# Altium schematic sheet 020_USBPort.SchDoc(020_USBPort)
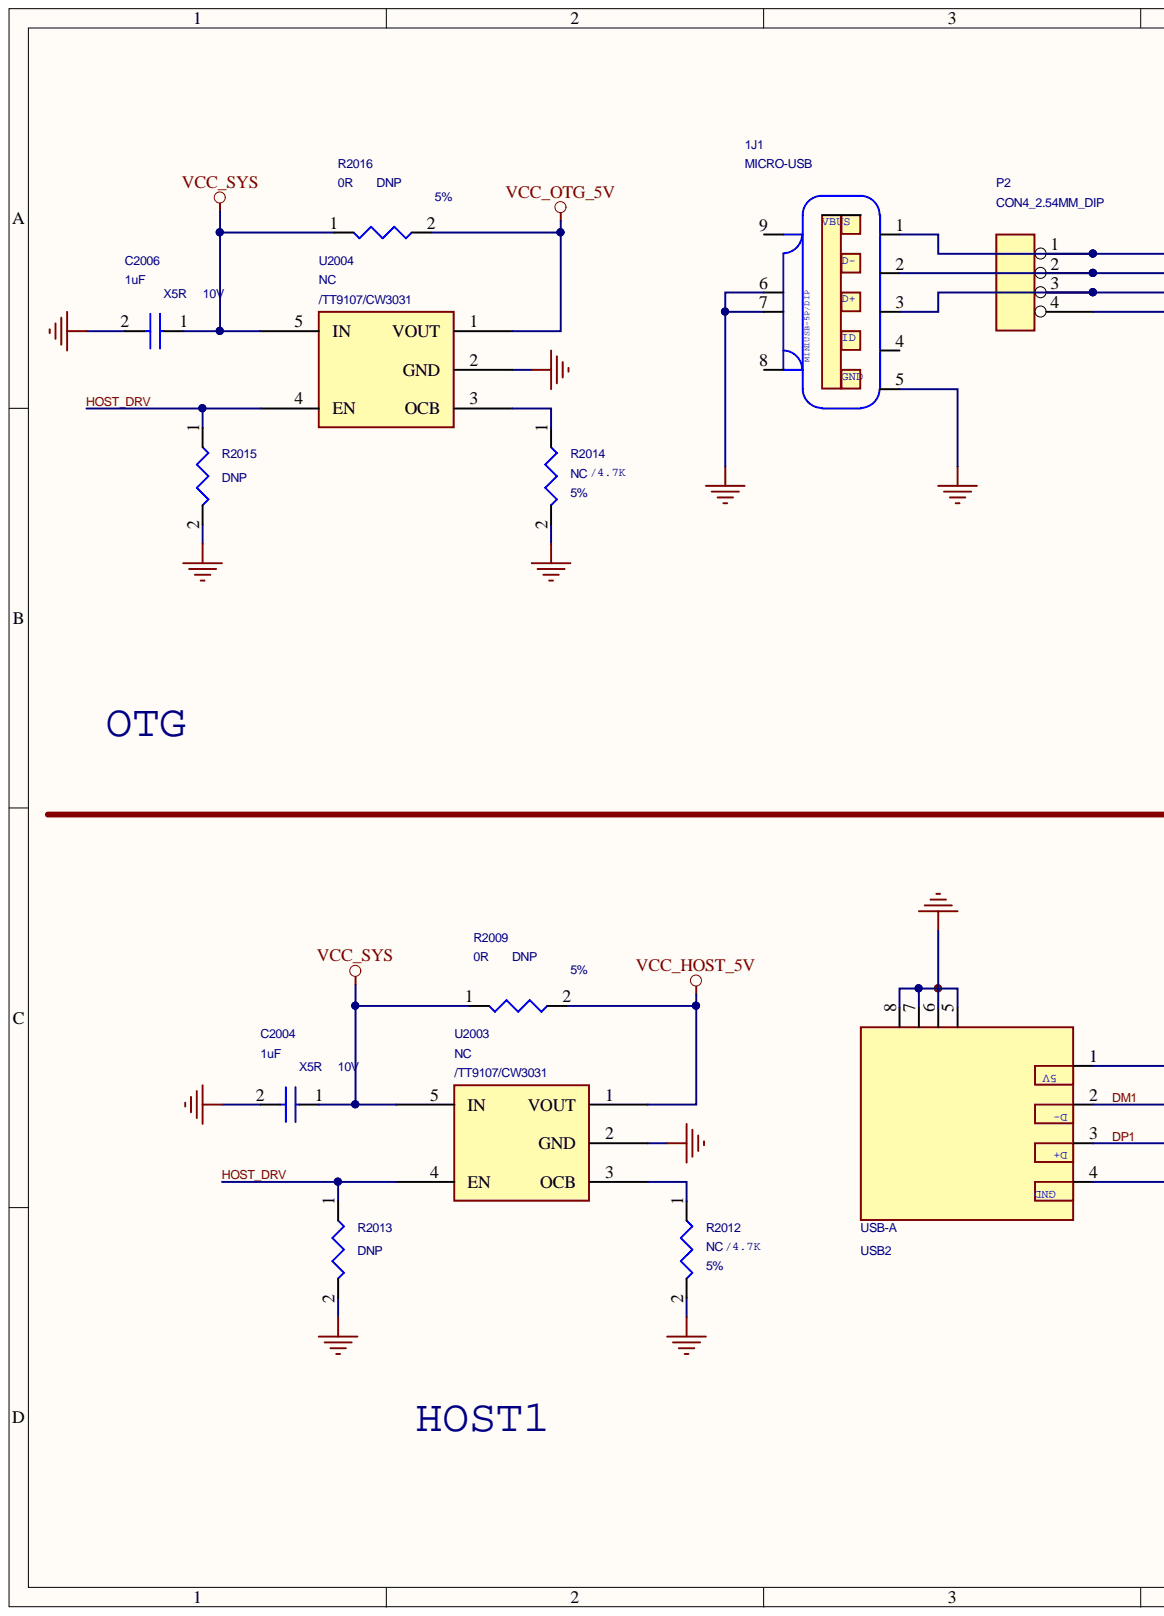
[diagram p0: 020_USBPort.SchDoc, left]
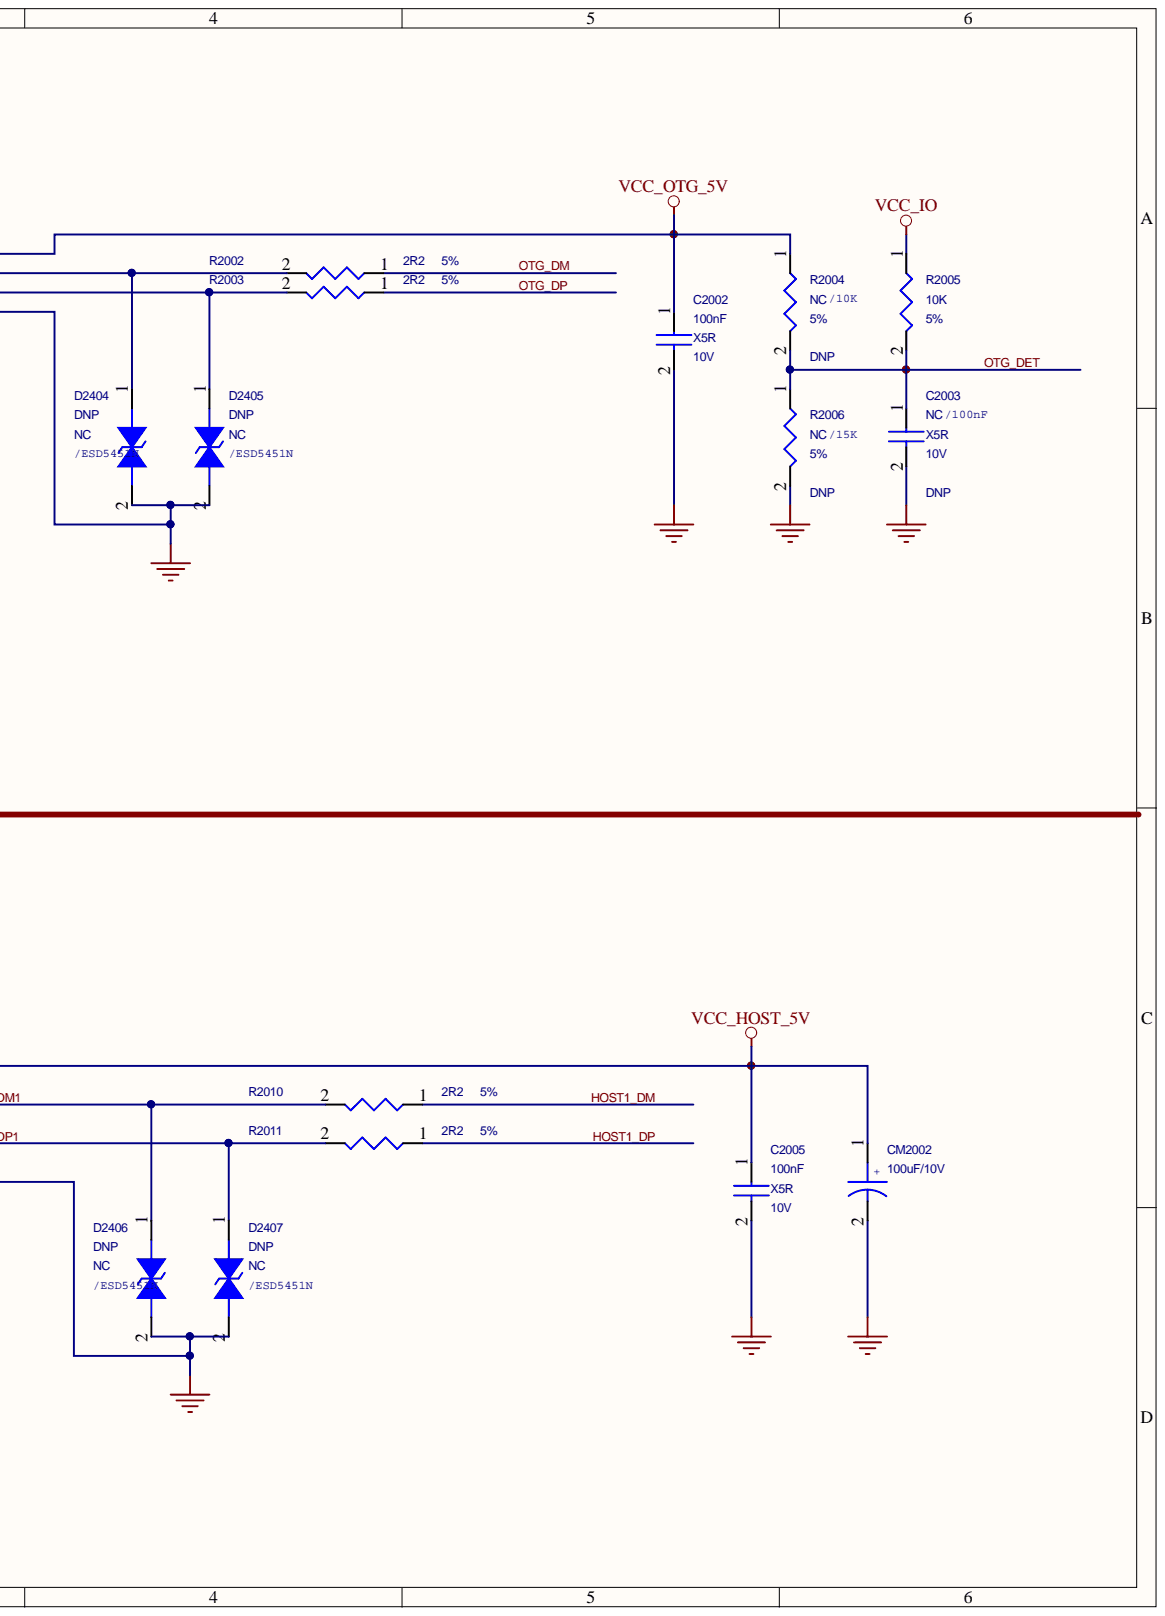
[diagram p1: 020_USBPort.SchDoc, right]

## Parts
1J1  MICRO-USB  [MINI-B]
C2002  100nF  Voltage: 10V  Dielectric: X5R  [C0402]
C2003  NC  Voltage: 10V  Option: DNP  Dielectric: X5R  [C0402]
C2004  1uF  Voltage: 10V  Dielectric: X5R  [C0402]
C2005  100nF  Voltage: 10V  Dielectric: X5R  [C0402]
C2006  1uF  Voltage: 10V  Dielectric: X5R  [C0402]
CM2002  100uF/10V  [MTM-C]
D2404  NC  OPTION: DNP  [ESD0402]
D2405  NC  OPTION: DNP  [ESD0402]
D2406  NC  OPTION: DNP  [ESD0402]
D2407  NC  OPTION: DNP  [ESD0402]
P2  CON4_2.54MM_DIP  [CON4_2.5MM_DIP]
R2002  2R2  [R0603]
R2003  2R2  [R0603]
R2004  NC  Tolerance: 5%  Option: DNP  [R0402]
R2005  10K  Tolerance: 5%  [R0402]
R2006  NC  Tolerance: 5%  Option: DNP  [R0402]
R2009  0R  Tolerance: 5%  [R0603]
R2010  2R2  [R0603]
R2011  2R2  [R0603]
R2012  NC  Tolerance: 5%  [R0402]
R2013  DNP  [R0402]
R2014  NC  Tolerance: 5%  [R0402]
R2015  DNP  [R0402]
R2016  0R  Tolerance: 5%  Option: DNP  [R0402]
U2003  NC  Option: /TT9107/CW3031  [SOT_23_5]
U2004  NC  Option: /TT9107/CW3031  [SOT_23_5]
USB2  USB-A  [USB-A-F-SIDE-DIP]

## Nets
GND: 1J1.5 1J1.6 1J1.7 C2002.2 C2003.2 C2004.2 C2005.2 C2006.2 CM2002.2 D2404.2 D2405.2 D2406.2 D2407.2 P2.4 R2006.2 R2012.2 R2013.2 R2014.2 R2015.2 U2003.2(GND) U2004.2(GND) USB2.4 USB2.5 USB2.6 USB2.7 USB2.8
VCC_HOST_5V: C2005.1 CM2002.1 R2009.2 U2003.1(VOUT) USB2.1
VCC_IO: R2005.1
VCC_OTG_5V: 1J1.1 C2002.1 P2.1 R2004.1 R2016.2 U2004.1(VOUT)
VCC_SYS: C2004.1 C2006.1 R2009.1 R2016.1 U2003.5(IN) U2004.5(IN)
DM1: D2406.1 R2010.2 USB2.2
DP1: D2407.1 R2011.2 USB2.3
HOST1_DM: R2010.1
HOST1_DP: R2011.1
HOST_DRV: R2013.1 R2015.1 U2003.4(EN) U2004.4(EN)
OTG_DET: C2003.1 R2004.2 R2005.2 R2006.1
OTG_DM: R2002.1
OTG_DP: R2003.1
Net1J1_2: 1J1.2 D2404.1 P2.2 R2002.2
Net1J1_3: 1J1.3 D2405.1 P2.3 R2003.2
NetR2012_1: R2012.1 U2003.3(OCB)
NetR2014_1: R2014.1 U2004.3(OCB)

Not connected: 1J1 4 8 9

## Library data of the parts
1J1 = JP_USB-0400ASMT_0: Part Type: Microb USB; Component Kind: Standard
C2002 C2003 C2005 = CAP NP_Dup5: cap,100.00nF,+/-10%,10V,X5R,C0402; Manufacturer: TDK; Tolerance: 10%; RK PN: C1005X5R1A104K050BA; Priority: A; Number: 37; C_Voltage: 6.3V; Part Type: C0402; Manufacturer PN: C1005X5R1A104K050BA; Component Kind: Standard; Created by: JJJ
C2004 C2006 = CAP NP_Dup5: cap,1.00uF,+/-10%,10V,X5R,C0402; Manufacturer: TDK; Tolerance: 10%; RK PN: C1005X5R1A105K050BB; Priority: A; C_Voltage: 6.3V; Part Type: C0402; Manufacturer PN: C1005X5R1A105K050BB; Component Kind: Standard; Created by: JJJ
CM2002 = CM_C: Component Kind: Standard
D2404-D2407 = DIODE BI_DIR TRIG: 1-Line, Bi-directional, Transient Voltage Suppressors; Manufacturer: WillSemi(甲먀군랄); RK PN: ESD5451N; Priority: A; Part Type: ESD_TVS; Manufacturer PN: ESD5451N; Component Kind: Standard; Created by: JJJ
P2 = CON4_2.5MM_DIP_Dup1: option: DNP; Component Kind: Standard
R2002 R2003 R2010 R2011 = RESISTOR_Dup1: 哥棨슌훡롯量,0R,+/-5%,R0603,1/10W.; Manufacturer: YAGEO; Wattage: 1/10W; Tolerance: 5%; RK PN: RC0603JR-070RL; Priority: A; Part Type: 哥棨슌훡롯量; Manufacturer PN: RC0603JR-070RL; Component Kind: Standard; Created by: YTL
R2004 R2005 = RESISTOR_Dup1: 哥棨슌훡롯量,10K,+/-5%,R0402,1/16W.; Manufacturer: YAGEO; Wattage: 1/16W; RK PN: RC0402JR-0710KL; Priority: A; Part Type: 哥棨슌훡롯量; Manufacturer PN: RC0402JR-0710KL; Component Kind: Standard; Created by: YTL
R2006 = RESISTOR_Dup1: 哥棨슌훡롯量,15K,+/-5%,R0402,1/16W.; Manufacturer: YAGEO; Wattage: 1/16W; RK PN: RC0402JR-0715KL; Priority: A; Part Type: 哥棨슌훡롯量; Manufacturer PN: RC0402JR-0715KL; Component Kind: Standard; Created by: YTL
R2009 = RESISTOR_Dup1: 哥棨슌훡롯量,0R,+/-5%,R1206,1/4W.; Manufacturer PN: RC0805JR-070RL; Manufacturer: YAGEO; RK PN: RC1206JR-070RL; Priority: A; Option: DNP; Part Type: 哥棨슌훡롯量; Component Kind: Standard; Created by: YTL
R2012 R2014 = RESISTOR_Dup1: 哥棨슌훡롯量,4K7,+/-5%,R0402,1/16W.; Manufacturer: YAGEO; Wattage: 1/16W; RK PN: RC0402JR-074K7L; Priority: A; Part Type: 哥棨슌훡롯量; Manufacturer PN: RC0402JR-074K7L; Component Kind: Standard; Created by: YTL
R2013 R2015 = RESISTOR_Dup1: Did Not Place.; Tolerance: 0%; RK PN: DNP0402; Priority: A; Part Type: DNP; Manufacturer PN: DNP0402; Component Kind: Standard; Created by: JJJ
R2016 = RESISTOR_Dup1: 哥棨슌훡롯量,0R,+/-5%,R1206,1/4W.; Manufacturer PN: RC0805JR-070RL; Manufacturer: YAGEO; RK PN: RC1206JR-070RL; Priority: A; Part Type: 哥棨슌훡롯量; Component Kind: Standard; Created by: YTL
U2003 U2004 = SWITCH_SY6280: Low Loss Power Distribution Switch; Manufacturer: Silergy?肩텝찾?; RK PN: SY6280AAC; Priority: A; Part Type: Switch; Manufacturer PN: SY6280AAC; Component Kind: Standard; Created by: JJJ
USB2 = USB-AF-SIDE-DIP_1: Component Kind: Standard
